# Altium schematic sheet JacdacRp2040BrainEc30 124.SchDoc("JacdacRp2040BrainEc30 124")
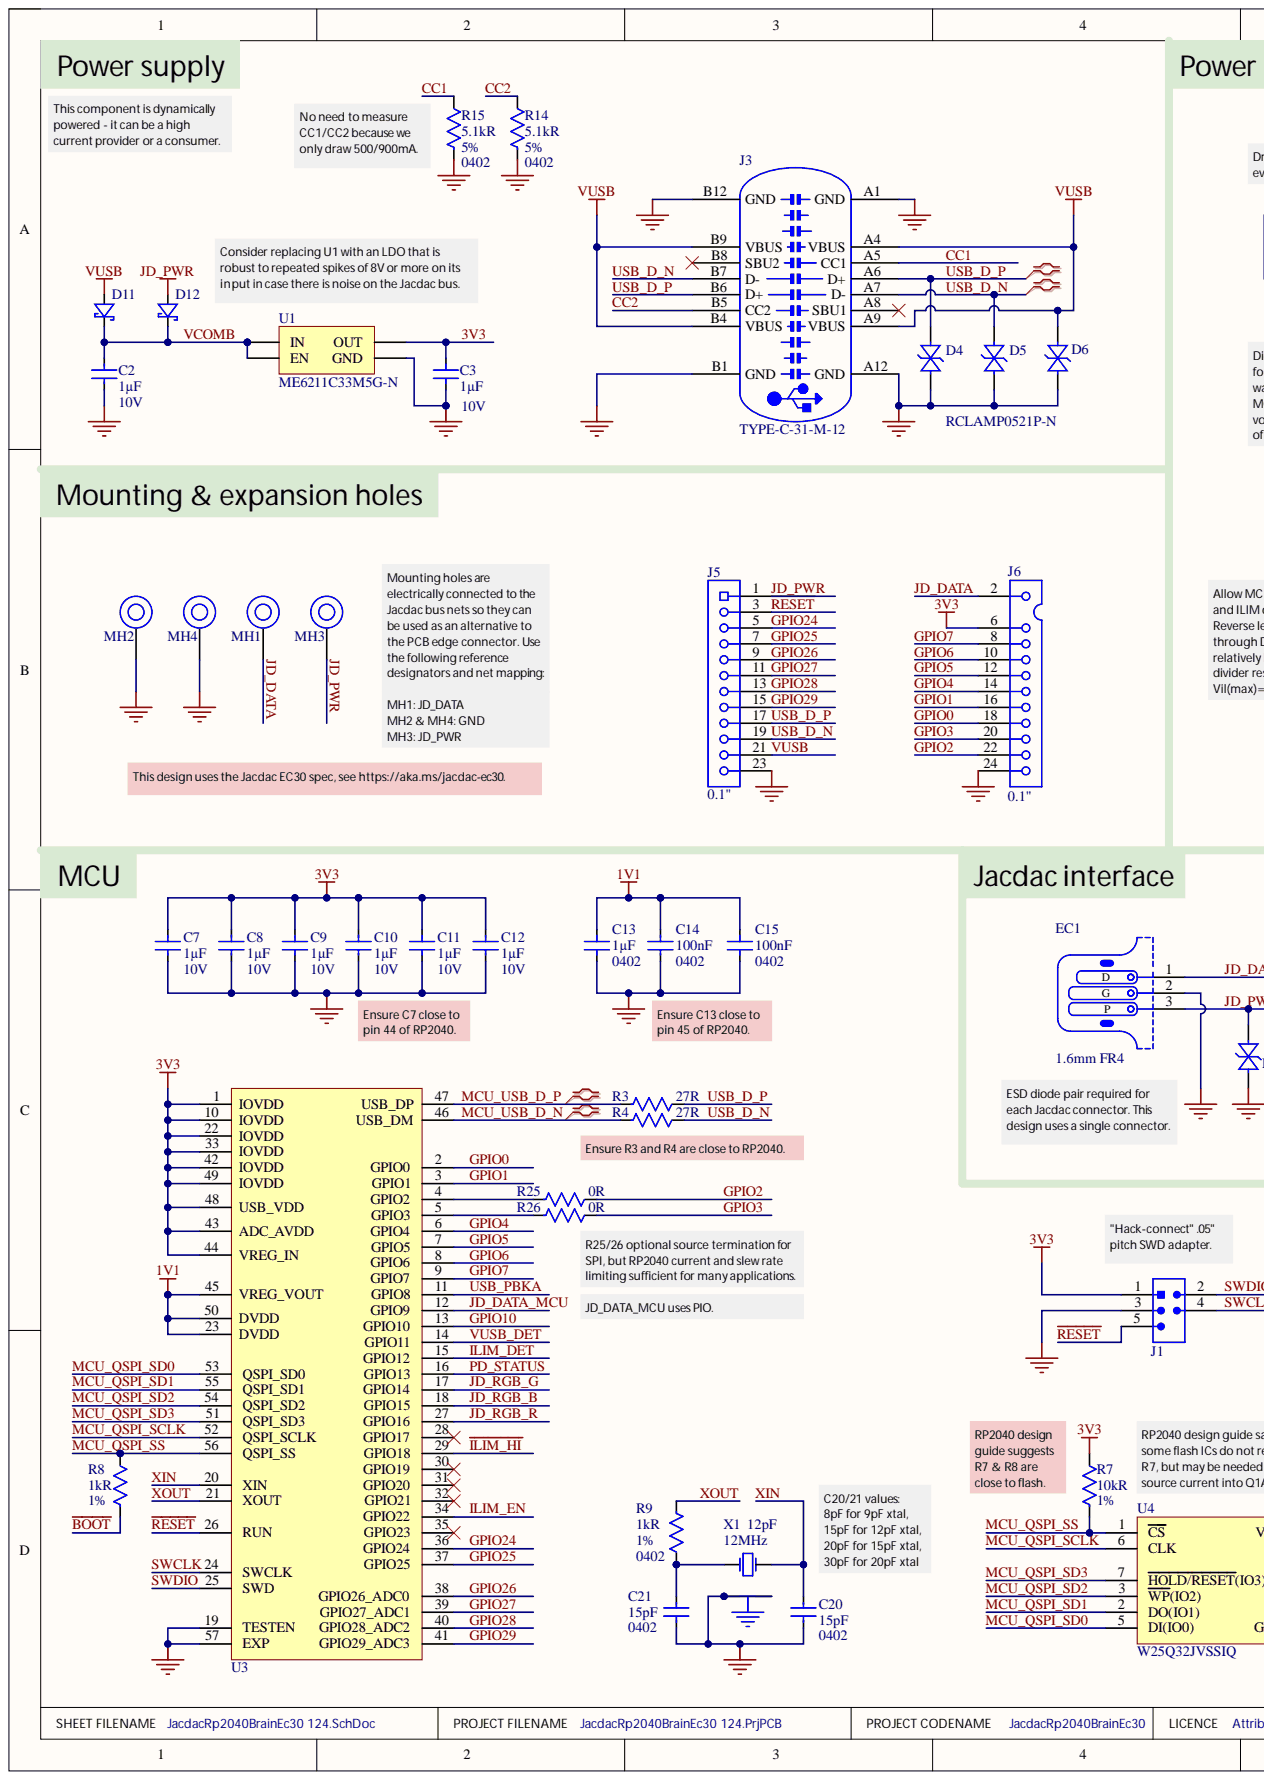
[diagram p0: JacdacRp2040BrainEc30 124.SchDoc, left]
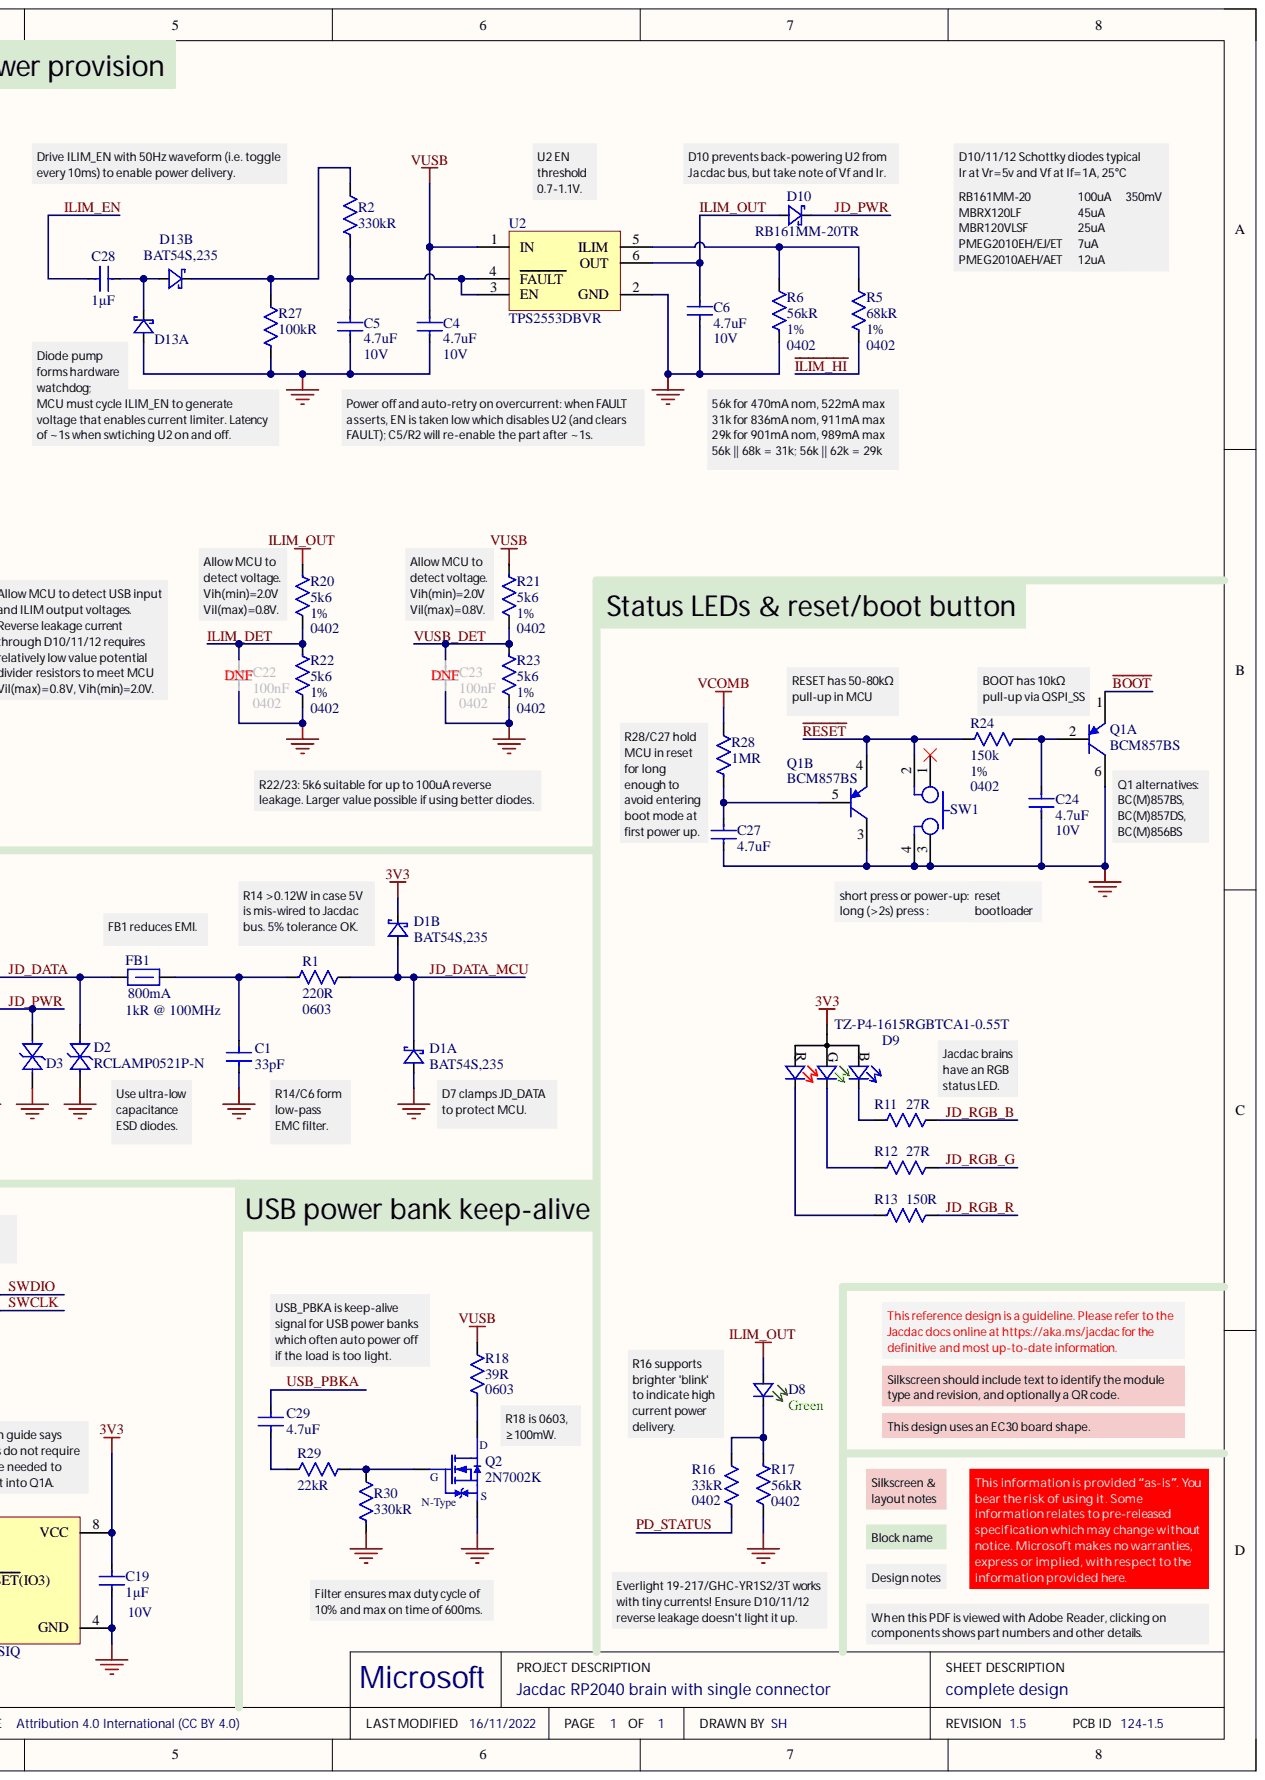
[diagram p1: JacdacRp2040BrainEc30 124.SchDoc, right]

## Parts
C1  Capacitance: 33pF
C2  Capacitance: 1µF  Voltage Rating: 10V
C3  Capacitance: 1µF  Voltage Rating: 10V
C4  Capacitance: 4.7uF  Voltage Rating: 10V
C5  Capacitance: 4.7uF  Voltage Rating: 10V
C6  Capacitance: 4.7uF  Voltage Rating: 10V
C7  Capacitance: 1µF  Voltage Rating: 10V
C8  Capacitance: 1µF  Voltage Rating: 10V
C9  Capacitance: 1µF  Voltage Rating: 10V
C10  Capacitance: 1µF  Voltage Rating: 10V
C11  Capacitance: 1µF  Voltage Rating: 10V
C12  Capacitance: 1µF  Voltage Rating: 10V
C13  Capacitance: 1µF  Case Code (Imperial): 0402
C14  Capacitance: 100nF  Case Code (Imperial): 0402
C15  Capacitance: 100nF  Case Code (Imperial): 0402
C19  Capacitance: 1µF  Voltage Rating: 10V
C20  Capacitance: 15pF  Case Code (Imperial): 0402
C21  Capacitance: 15pF  Case Code (Imperial): 0402
C22  Capacitance: 100nF  Case Code (Imperial): 0402
C23  Capacitance: 100nF  Case Code (Imperial): 0402
C24  Capacitance: 4.7uF  Voltage Rating: 10V
C27  Capacitance: 4.7uF
C28  Capacitance: 1µF
C29  Capacitance: 4.7uF
D1  Manufacturer Part Number: BAT54S,235  (parts A,B)
D2  Manufacturer Part Number: RCLAMP0521P-N
D3  (no value)
D4  Manufacturer Part Number: RCLAMP0521P-N
D5  Manufacturer Part Number: RCLAMP0521P-N
D6  Manufacturer Part Number: RCLAMP0521P-N
D8  Color: Green
D9  Manufacturer Part Number: TZ-P4-1615RGBTCA1-0.55T
D10  Manufacturer Part Number: RB161MM-20TR
D11  (no value)
D12  (no value)
D13  Manufacturer Part Number: BAT54S,235  (parts A,B)
EC1  PCB Type: 1.6mm FR4
FB1  Current Rating: 800mA  Impedance: 1kR @ 100MHz
J1  (no value)
J3  Manufacturer Part Number: TYPE-C-31-M-12
J5  (no value)
J6  (no value)
MH1  (no value)
MH2  (no value)
MH3  (no value)
MH4  (no value)
Q1  (no value)  (parts A,B)
Q2  Manufacturer Part Number: 2N7002K
R1  Case Code (Imperial): 0603  Resistance: 220R
R2  Resistance: 330kR
R3  Resistance: 27R
R4  Resistance: 27R
R5  Case Code (Imperial): 0402  Resistance: 68kR  Tolerance: 1%
R6  Case Code (Imperial): 0402  Resistance: 56kR  Tolerance: 1%
R7  Resistance: 10kR  Tolerance: 1%
R8  Resistance: 1kR  Tolerance: 1%
R9  Case Code (Imperial): 0402  Resistance: 1kR  Tolerance: 1%
R11  Resistance: 27R
R12  Resistance: 27R
R13  Resistance: 150R
R14  Case Code (Imperial): 0402  Resistance: 5.1kR  Resistance Tolerance: 5%
R15  Case Code (Imperial): 0402  Resistance: 5.1kR  Resistance Tolerance: 5%
R16  Case Code (Imperial): 0402  Resistance: 33kR
R17  Case Code (Imperial): 0402  Resistance: 56kR
R18  Case Code (Imperial): 0603  Resistance: 39R
R20  Case Code (Imperial): 0402  Resistance: 5k6  Tolerance: 1%
R21  Case Code (Imperial): 0402  Resistance: 5k6  Tolerance: 1%
R22  Case Code (Imperial): 0402  Resistance: 5k6  Tolerance: 1%
R23  Case Code (Imperial): 0402  Resistance: 5k6  Tolerance: 1%
R24  Resistance: 150k  Tolerance: 1%
R25  Resistance: 0R
R26  Resistance: 0R
R27  Resistance: 100kR
R28  Resistance: 1MR
R29  Resistance: 22kR
R30  Resistance: 330kR
SW1  (no value)
U1  Manufacturer Part Number: ME6211C33M5G-N
U2  Manufacturer Part Number: TPS2553DBVR
U3  (no value)
U4  Manufacturer Part Number: W25Q32JVSSIQ
X1  Frequency: 12MHz  Loading capacitance: 12pF

## Nets
1V1: C13.2 C14.2 C15.2 U3.23(DVDD) U3.45(VREG_VOUT) U3.50(DVDD)
GND: C1.1 C2.1 C3.1 C4.1 C5.1 C6.1 C7.1 C8.1 C9.1 C10.1 C11.1 C12.1 C13.1 C14.1 C15.1 C19.1 C20.2 C21.2 C22.1 C23.1 C24.1 C27.1 D1.1 D2.2 D3.2 D4.2 D5.2 D6.2 D13.1 EC1.2 J1.3 J3.A1(GND) J3.A12(GND) J3.B1(GND) J3.B12(GND) J5.23 J6.24 MH2.1 MH4.1 Q1.3 Q1.6 Q2.2 R6.1 R14.2 R15.2 R17.2 R22.1 R23.1 R27.1 R30.2 SW1.3 SW1.4 U1.2(GND) U2.2(GND) U3.19(TESTEN) U3.57(EXP) U4.4(GND) X1.2 X1.4
3V3: C3.2 C7.2 C8.2 C9.2 C10.2 C11.2 C12.2 C19.2 D1.2 D9.1 J1.1 J6.6 R7.1 U1.5(OUT) U3.1(IOVDD) U3.10(IOVDD) U3.22(IOVDD) U3.33(IOVDD) U3.42(IOVDD) U3.43(ADC_AVDD) U3.44(VREG_IN) U3.48(USB_VDD) U3.49(IOVDD) U4.8(VCC)
~{BOOT}: Q1.1 R8.2
CC1: J3.A5(CC1) R15.1
CC2: J3.B5(CC2) R14.1
GPIO0: J6.18 U3.2(GPIO0)
GPIO1: J6.16 U3.3(GPIO1)
GPIO2: J6.22 R25.2
GPIO3: J6.20 R26.2
GPIO4: J6.14 U3.6(GPIO4)
GPIO5: J6.12 U3.7(GPIO5)
GPIO6: J6.10 U3.8(GPIO6)
GPIO7: J6.8 U3.9(GPIO7)
GPIO10: U3.13(GPIO10)
GPIO24: J5.5 U3.36(GPIO24)
GPIO25: J5.7 U3.37(GPIO25)
GPIO26: J5.9 U3.38(GPIO26_ADC0)
GPIO27: J5.11 U3.39(GPIO27_ADC1)
GPIO28: J5.13 U3.40(GPIO28_ADC2)
GPIO29: J5.15 U3.41(GPIO29_ADC3)
~{ILIM_HI}: R5.1 U3.29(GPIO18)
ILIM_DET: C22.2 R20.1 R22.2 U3.15(GPIO12)
ILIM_EN: C28.2 U3.34(GPIO22)
ILIM_OUT: C6.2 D8.2 D10.2 R20.2 U2.6(OUT)
JD_DATA: D2.1 EC1.1 FB1.1 J6.2 MH1.1
JD_DATA_MCU: D1.3 R1.2 U3.12(GPIO9)
JD_PWR: D3.1 D10.1 D12.2 EC1.3 J5.1 MH3.1
JD_RGB_B: R11.2 U3.18(GPIO15)
JD_RGB_G: R12.2 U3.17(GPIO14)
JD_RGB_R: R13.2 U3.27(GPIO16)
MCU_QSPI_SCLK: U3.52(QSPI_SCLK) U4.6(CLK)
MCU_QSPI_SD0: U3.53(QSPI_SD0) U4.5(DI(IO0))
MCU_QSPI_SD1: U3.55(QSPI_SD1) U4.2(DO(IO1))
MCU_QSPI_SD2: U3.54(QSPI_SD2) U4.3(WP(IO2))
MCU_QSPI_SD3: U3.51(QSPI_SD3) U4.7(HOLD/RESET(IO3))
MCU_QSPI_SS: R7.2 R8.1 U3.56(QSPI_SS) U4.1(CS)
MCU_USB_D_N: R4.1 U3.46(USB_DM)
MCU_USB_D_P: R3.1 U3.47(USB_DP)
PD_STATUS: R16.1 U3.16(GPIO13)
~{RESET}: J1.5 J5.3 Q1.4 R24.1 SW1.2 U3.26(RUN)
SWCLK: J1.4 U3.24(SWCLK)
SWDIO: J1.2 U3.25(SWD)
USB_D_N: D5.1 J3.A7(D-) J3.B7(D-) J5.19 R4.2
USB_D_P: D4.1 J3.A6(D+) J3.B6(D+) J5.17 R3.2
USB_PBKA: C29.1 U3.11(GPIO8)
VCOMB: C2.2 D11.1 D12.1 R28.1 U1.1(IN) U1.3(EN)
VUSB: C4.2 D6.1 D11.2 J3.A4(VBUS) J3.A9(VBUS) J3.B4(VBUS) J3.B9(VBUS) J5.21 R18.2 R21.2 U2.1(IN)
VUSB_DET: C23.2 R21.1 R23.2 U3.14(GPIO11)
XIN: C20.1 U3.20(XIN) X1.3
XOUT: R9.2 U3.21(XOUT)
NetC1_2: C1.2 FB1.2 R1.1
NetC5_2: C5.2 R2.2 U2.3(EN) U2.4(FAULT)
NetC21_1: C21.1 R9.1 X1.1
NetC24_2: C24.2 Q1.2 R24.2
NetC27_2: C27.2 Q1.5 R28.2
NetC28_1: C28.1 D13.3
NetC29_2: C29.2 R29.2
NetD8_1: D8.1 R16.2 R17.1
NetD9_2: D9.2 R13.1
NetD9_3: D9.3(G) R12.1
NetD9_4: D9.4 R11.1
NetD13_2: D13.2 R2.1 R27.2
NetQ2_1: Q2.1 R29.1 R30.1
NetQ2_3: Q2.3 R18.1
NetR5_2: R5.2 R6.2 U2.5(ILIM)
NetR25_1: R25.1 U3.4(GPIO2)
NetR26_1: R26.1 U3.5(GPIO3)

Not connected: J3 A8(SBU1) B8(SBU2); SW1 1; U3 28(GPIO17) 30(GPIO19) 31(GPIO20) 32(GPIO21) 35(GPIO23)

## Library data of the parts
C1 = (no symbol name): CAP CER 33PF 50V NP0 0402; Manufacturer: Samsung; Manufacturer Part Number: CL05C330JB51PNC; Tolerance: 5%; Case Code (Imperial): 0402; Case Code (Metric): 1005; Case/Package: 0402; Category: Capacitors; ComponentLink1Description: Samsung datasheet online; ComponentLink1URL: http://www.samsungsem.com/kr/support/library/product-catalog/mlcc.jsp; Max Operating Temperature: 125°C; Min Operating Temperature: -55°C; Ratings: AEC-Q200; Supplier 1: Digi-Key; Supplier 2: Mouser; Supplier 3: Future Electronics; Supplier Part Number 1: 1276-6515-1-ND; Supplier Part Number 2: 187-CL05C330JB51PNC; Supplier Part Number 3: 6022520; Voltage Rating: 50V
C2 C3 C7-C13 C19 C28 = (no symbol name): CAP CER 1UF 10V X5R 0402; Manufacturer: Samsung; Manufacturer Part Number: CL05A105KP5NNNC; Material: Ceramic; Tolerance: 10%; Case Code (Metric): 1005; Case/Package: 0402; Category: Capacitors; ComponentLink1Description: Online datasheet; ComponentLink1URL: https://datasheet.ciiva.com/15195/getdatasheetpartid-358390-15195630.pdf; Contact Plating: Tin; Dielectric: X5R; Max Operating Temperature: 85°C; Min Operating Temperature: -55°C; Supplier 1: Digi-Key; Supplier 2: Mouser; Supplier 3: Farnell; Supplier Part Number 1: 1276-1076-1-ND; Supplier Part Number 2: 187-CL05A105KP5NNNC; Supplier Part Number 3: 3013329
  C2 C3 C7-C12 C19: Case Code (Imperial): 0402
  C13: Voltage Rating: 10V
  C28: Case Code (Imperial): 0402; Voltage Rating: 10V
C4-C6 C24 C27 C29 = (no symbol name): CAP CER 4.7UF 10V X5R 0402; Manufacturer: Samsung; Manufacturer Part Number: CL05A475MP5NRNC; Tolerance: 20%; Case Code (Imperial): 0402; Case Code (Metric): 1005; Case/Package: 0402; Category: Ceramic Capacitors; ComponentLink1Description: Online datasheet; ComponentLink1URL: https://datasheet.ciiva.com/25786/cl05a475mp5nrnc-25786963.pdf; Dielectric: X5R; Max Operating Temperature: 85°C; Min Operating Temperature: -55°C; RoHS Compliant: Yes; Series: CL; Supplier 1: Digi-Key; Supplier 2: Future Electronics; Supplier Part Number 1: 1276-1482-2-ND; Supplier Part Number 2: 2103694
  C27 C29: Voltage Rating: 10V
C14 C15 C22 C23 = (no symbol name): CAP CER 0.1UF 10V X7R 0402; Manufacturer: Samsung; Manufacturer Part Number: CL05B104KP5NNNC; Tolerance: 10%; Case Code (Metric): 1005; Case/Package: 0402; Category: Ceramic Capacitors; ComponentLink1Description: Samsung datasheet online; ComponentLink1URL: https://datasheet.ciiva.com/15165/getdatasheetpartid-37100-15165107.pdf; Contact Plating: Tin; Dielectric: X7R; Max Operating Temperature: 125°C; Min Operating Temperature: -55°C; Supplier 1: Digi-Key; Supplier 2: Mouser; Supplier 3: Farnell; Supplier 4: Newark; Supplier 5: Future Electronics; Supplier Part Number 1: 1276-1002-1-ND; Supplier Part Number 2: 187-CL05B104KP5NNNC; Supplier Part Number 3: 3013351; Supplier Part Number 4: 82AC9219; Supplier Part Number 5: 9032525; Voltage Rating: 10V
C20 C21 = (no symbol name): CAP CER 15PF 50V C0G/NP0 0402; Manufacturer: Samsung; Manufacturer Part Number: CL05C150JB5NNNC; Dielectric: C0G; Material: Ceramic; Temperature Coefficient: 300ppm/°C; Tolerance: 5%; Case Code (Metric): 1005; Case/Package: 0402; Category: Ceramic Capacitors; ComponentLink1Description: Online datasheet; ComponentLink1URL: https://datasheet.ciiva.com/15195/getdatasheetpartid-497170-15195669.pdf; Construction: Flat; Contact Plating: Tin; Max Operating Temperature: 125°C; Min Operating Temperature: -55°C; RoHS Compliant: Yes; Series: CL; Supplier 1: Digi-Key; Supplier 2: Mouser; Supplier Part Number 1: 1276-1179-1-ND; Supplier Part Number 2: 187-CL05C150JB5NNNC; Voltage: 50V; Voltage Rating: 50V
D1 D13 = (no symbol name): DIODE ARRAY SCHOTTKY 30V SOT23; Manufacturer: Nexperia; Category: Rectifier Diodes; ComponentLink1Description: NXP datasheet online; ComponentLink1URL: https://assets.nexperia.com/documents/data-sheet/BAT54_SER.pdf; Supplier 1: Digi-Key; Supplier 2: Mouser; Supplier 3: RSComponents; Supplier Part Number 1: 1727-1868-1-ND; Supplier Part Number 2: 771-BAT54S235; Supplier Part Number 3: 1707990
D2 D4-D6 = (no symbol name): Bidirectional 5V (Max) 6V 16V 3.5A (8/20us) 0402 TVS RoHS; Manufacturer: Born Semiconductor; ComponentLink1Description: Online datasheet; ComponentLink1URL: https://datasheet.lcsc.com/szlcsc/Bourne-Semicon-Shenzhen-RCLAMP0521P-N_C316043.pdf; Manufacturer 1: Born Semiconductor; Manufacturer Part Number 1: RCLAMP0521P-N; Package: 0402; Supplier 1: LCSC; Supplier Part Number 1: C316043
D3 = (no symbol name): Bidirectional 5V (Max) 6V 16V 3.5A (8/20us) 0402 TVS RoHS; Manufacturer: Born Semiconductor; Manufacturer Part Number: RCLAMP0521P-N; ComponentLink1Description: Online datasheet; ComponentLink1URL: https://datasheet.lcsc.com/szlcsc/Bourne-Semicon-Shenzhen-RCLAMP0521P-N_C316043.pdf; Manufacturer 1: Born Semiconductor; Manufacturer Part Number 1: RCLAMP0521P-N; Package: 0402; Supplier 1: LCSC; Supplier Part Number 1: C316043
D8 = (no symbol name): LED GREEN DIFFUSED 0603 SMD; Manufacturer: Everlight Elec; Manufacturer Part Number: 19-217/GHC-YR1S2/3T; Case Code (Imperial): 0603; Case/Package: 0603; Colour: Green; ComponentLink1Description: Online datasheet; ComponentLink1URL: https://datasheet.lcsc.com/lcsc/1811101510_Everlight-Elec-19-217-GHC-YR1S2-3T_C72043.pdf; Manufacturer 1: Everlight Elec; Manufacturer Part Number 1: 19-217/GHC-YR1S2/3T; Supplier 1: LCSC; Supplier Part Number 1: C72043
D9 = (no symbol name): 1615 Light Emitting Diodes (LED) RoHS; Manufacturer: TUOZHAN; Category: Optoelectronics; ComponentLink1Description: Online datasheet; ComponentLink1URL: https://datasheet.lcsc.com/szlcsc/2009041238_TUOZHAN-TZ-P4-1615RGBTCA1-0-55T_C779813.pdf; Manufacturer 1: TUOZHAN; Manufacturer Part Number 1: TZ-P4-1615RGBTCA1-0.55T; Package: 1615; Size / Dimension: 1.6x1.5mm; Supplier 1: LCSC; Supplier Part Number 1: C779813
D10-D12 = (no symbol name): ROHM - RB161MM-20TR - Schottky-Gleichrichterdiode, 20 V, 1 A, Einfach, SOD-123FL, 2 Pin(s), 350 mV; Average Rectified Current: 1A; Max Reverse Voltage (DC): 25V; Case/Package: SOD; Category: Rectifier Diodes; ComponentLink1Description: Online datasheet; ComponentLink1URL: http://www.mouser.com/ds/2/348/rb161mm-20tr-e-1138856.pdf; Manufacturer: Rohm; Max Operating Temperature: 125°C; Number of Pins: 2; RoHS Compliant: Yes; Supplier 1: Digi-Key; Supplier 2: RSComponents; Supplier 3: Mouser; Supplier Part Number 1: RB161MM-20TR-ND; Supplier Part Number 2: 1246775; Supplier Part Number 3: 755-RB161MM-20TR
  D11 D12: Manufacturer Part Number: RB161MM-20TR
EC1 = (no symbol name): 3 pin Jacdac edge connector 1.27mm pitch; ComponentLink1Description: Yuliang JACDAC cable connector drawing online; ComponentLink1URL: https://github.com/microsoft/jacdac-ddk/tree/main/connector; ComponentLink2Description: JACDAC PCB edge connector spec onlin; ComponentLink2URL: https://github.com/microsoft/jacdac-ddk/tree/main/connector; Manufacturer Part Number: n/a
FB1 = (no symbol name): 0603 1k@100MHz 0.8A chip; Manufacturer: TDK; Manufacturer Part Number: MPZ1608S102ATA00; Core Material: Ferrite; DC Resistance (DCR): 300mR; Tolerance: 25%; Case Code (Imperial): 0603; Case Code (Metric): 1608; Case/Package: 0603; ComponentLink2Description: datasheet online; ComponentLink2URL: https://www.mouser.co.uk/datasheet/2/400/eads_commercial_power_mpz1608_en-923650.pdf; ComponentLink3Description: datasheet; Max DC Current: 800mA; Supplier 1: Digi-Key; Supplier 2: Mouser; Supplier Part Number 1: 445-8694-1-ND; Supplier Part Number 2: 810-MPZ1608S102ATA00; ComponentLink3URL: Y:\System\Altium\Libraries 2014\TDK\Datasheets\eads_commercial_power_mpz1608_en-923650.pdf
J1 = (no symbol name): Hack Connect Mini 1.27 mm pitch 2-row
J3 = (no symbol name): USB - Type C Female 16 USB Connectors RoHS; Manufacturer: Korean Hroparts Elec; Gender: Receptacle; Number of Positions: 16; Category: USB Connectors; ComponentLink1Description: Online datasheet; ComponentLink1URL: https://datasheet.lcsc.com/szlcsc/1811131825_Korean-Hroparts-Elec-TYPE-C-31-M-12_C165948.pdf; Manufacturer 1: Korean Hroparts Elec; Manufacturer Part Number 1: TYPE-C-31-M-12; Orientation: Right Angle; Supplier 1: LCSC; Supplier Part Number 1: C165948
J5 J6 = (no symbol name): 2.54mm, 12x1 way, vertical, TH; Manufacturer: Generic; Manufacturer Part Number: TBD
MH1-MH4 = (no symbol name): Mounting Hole 7.0mm keepout, 4.4mm annualar ring and 3.1mm Drill PTH; Hole Size: M3; Annular Ring: 4.4mm; Hole Clearance: 7.0mm; Hole Diameter: 3.1mm; Hole Type: PTH
Q1 = (no symbol name): BJT ARRAY, DUAL PNP, -45V, -100MA, 6-SOT-363; Manufacturer: NXP Semiconductors; Manufacturer Part Number: BCM857BS,115; Collector Base Voltage (VCBO): 50V; Collector Emitter Breakdown Voltage: 45V; Emitter Base Voltage (VEBO): 5V; Frequency: 175MHz; Max Collector Current: 100mA; Number of Elements: 2; Test Current: 5mA; Tolerance: 5%; Zener Voltage: 3.3V; Case/Package: SOT-363; Collector Emitter Voltage (VCEO): 45V; ComponentLink1Description: NXP datasheet online; ComponentLink1URL: https://assets.nexperia.com/documents/data-sheet/BCM857BV_BS_DS.pdf; Contact Plating: Tin; Element Configuration: Dual; Gain Bandwidth Product: 175MHz; Max Breakdown Voltage: 45V; Number of Pins: 6; Polarity: PNP; Transition Frequency: 175MHz; Weight: 0.01lb
Q2 = (no symbol name): MOSFET Transistor, N Channel, 300 mA, 60 V, 2 ohm, 10 V, 2.5 V; Manufacturer: ON Semiconductor / Fairchild; Continuous Drain Current (ID): 300mA; Drain to Source Breakdown Voltage: 60V; Drain to Source Resistance: 2R; Element Configuration: Single; Gate to Source Voltage (Vgs): 20V; Input Capacitance: 50pF; Max Power Dissipation: 350mW; Number of Elements: 1; Polarity: N-CHANNEL; Threshold Voltage: 2.5V; Turn-Off Delay Time: 30ns; Turn-On Delay Time: 5ns; Case/Package: SOT-23; Category: MOSFETs; ComponentLink1Description: Online datasheet; ComponentLink1URL: https://www.onsemi.com/pdf/datasheet/2n7002k-d.pdf; Contact Plating: Tin,Matte; Drain to Source Voltage (Vdss): 60V; Drain-source On Resistance-Max: 2R; Max Operating Temperature: 150°C; Min Operating Temperature: -55°C; Number of Pins: 3; On-State Resistance: 2R; Power Dissipation: 350mW; Rds On Max: 2R; Supplier 1: Digi-Key; Supplier 2: Mouser; Supplier 3: Farnell; Supplier Part Number 1: 2N7002KCT-ND; Supplier Part Number 2: 512-2N7002K; Supplier Part Number 3: 2453382; Voltage: 60V
R1 = (no symbol name): Res Thick Film 0603 220 Ohm 1% 0.1W(1/10W) ±100ppm/°C Pad SMD T/R; Manufacturer: Yageo; Manufacturer Part Number: RC0603FR-07220RL; Max Power Dissipation: 100mW; Voltage Rating: 50V; Case Code (Metric): 1608; Case/Package: 0603; Category: Chip SMD Resistors; ComponentLink4Description: online datasheet; ComponentLink4URL: https://datasheet.ciiva.com/46395/443971-46395002.pdf; Construction: Rectangular; Features: Moisture Resistant; Manufacturer 1: Yageo; Manufacturer Part Number 1: RC0603FR-07220RL; Max Operating Temperature: 155°C; Min Operating Temperature: -55°C; Number of Pins: 2; Number of Terminations: 2; Power Rating: 100mW; Resistance Tolerance: 1%; Resistor Type: Thick Film; RoHS Compliant: Yes; Series: RC; Size Code: 0603; Supplier 1: Digi-Key; Supplier 2: Farnell; Supplier 3: Mouser; Supplier 4: RSComponents; Supplier Part Number 1: 311-220HRCT-ND; Supplier Part Number 2: 2421863; Supplier Part Number 3: 603-RC0603FR-07220RL; Supplier Part Number 4: 1995497; Temperature Coefficient: 100ppm/°C; Termination: SMD/SMT; Tolerance: 1%; Voltage Rating (DC): 50V
R2 R30 = (no symbol name): RES SMD 330K OHM 1% 1/16W 0402; Manufacturer: Yageo; Manufacturer Part Number: RC0402FR-07330KL; Max Power Dissipation: 63mW; Temperature Coefficient: 100ppm/°C; Voltage Rating: 50V; Case Code (Imperial): 0402; Case Code (Metric): 1005; Case/Package: 0402; Category: Chip SMD Resistors; ComponentLink1Description: Online datasheet; ComponentLink1URL: https://datasheet.ciiva.com/2518/pyu-r-int-thick-7-2518994.pdf?src-supplier=Digi-Key; Construction: Rectangular; Features: Moisture Resistant; Max Operating Temperature: 155°C; Min Operating Temperature: -55°C; Number of Terminations: 2; Power Rating: 63mW; Resistance Tolerance: 1%; Resistor Type: Thick Film; RoHS Compliant: Yes; Series: RC; Size Code: 0402; Supplier 1: Digi-Key; Supplier 2: Mouser; Supplier 3: Farnell; Supplier Part Number 1: 311-330KLRCT-ND; Supplier Part Number 2: 603-RC0402FR-07330KL; Supplier Part Number 3: 9239537; Termination: SMD/SMT; Tolerance: 1%
R3 R4 R11 R12 = (no symbol name): RES SMD 27 OHM 1% 1/16W 0402; Manufacturer: Yageo; Manufacturer Part Number: RC0402FR-0727RL; Max Power Dissipation: 63mW; Power Rating: 62.5mW; Temperature Coefficient: 100ppm/°C; Voltage Rating: 50V; Case Code (Imperial): 0402; Case Code (Metric): 1005; Case/Package: 0402; Category: Chip SMD Resistors; ComponentLink1Description: Online datasheeet; ComponentLink1URL: https://datasheet.ciiva.com/11808/0900766b80c66297-11808450.pdf; Construction: Rectangular; Features: Moisture Resistant; Max Operating Temperature: 155°C; Min Operating Temperature: -55°C; Number of Terminations: 2; Resistance Tolerance: 1%; Resistor Type: Thick Film; RoHS Compliant: Yes; Series: RC; Size Code: 0402; Supplier 1: Digi-Key; Supplier 2: Farnell; Supplier 3: Future Electronics; Supplier Part Number 1: YAG3086CT-ND; Supplier Part Number 2: 9239049; Supplier Part Number 3: 2577120; Technology: THICK FILM; Termination: SMD/SMT; Tolerance: 1%; Weight: 2.3E-05oz
R5 = (no symbol name): RES SMD 68K OHM 1% 1/16W 0402; Manufacturer: Yageo; Manufacturer Part Number: RC0402FR-0768KL; Max Power Dissipation: 63mW; Temperature Coefficient: 100ppm/°C; Voltage Rating: 50V; Case Code (Metric): 1005; Case/Package: 0402; Category: Resistors; ComponentLink2Description: Online datasheet; ComponentLink2URL: https://datasheet.ciiva.com/2453/pyu-r-int-thick-7-2453361.pdf; Features: Moisture Resistant; Max Operating Temperature: 155°C; Min Operating Temperature: -55°C; Number of Terminations: 2; Power Rating: 63mW; Resistor Type: Thick Film; Termination: SMD/SMT; Working Voltage: 50V
R6 R17 = (no symbol name): RES SMD 56K OHM 1% 1/16W 0402; Manufacturer: Yageo; Manufacturer Part Number: RC0402FR-0756KL; Max Power Dissipation: 63mW; Temperature Coefficient: 100ppm/°C; Voltage Rating: 50V; Case Code (Metric): 1005; Case/Package: 0402; Category: Resistors; ComponentLink2Description: Online datasheet; ComponentLink2URL: https://datasheet.ciiva.com/2453/pyu-r-int-thick-7-2453361.pdf; Features: Moisture Resistant; Max Operating Temperature: 155°C; Min Operating Temperature: -55°C; Number of Terminations: 2; Power Rating: 63mW; Resistor Type: Thick Film; Termination: SMD/SMT; Working Voltage: 50V
  R17: Tolerance: 1%
R7 = (no symbol name): RES SMD 10K OHM 1% 1/16W 0402; Manufacturer: Yageo; Manufacturer Part Number: RC0402FR-0710KL; Power Rating: 62.5mW; Temperature Coefficient: 100ppm/°C; Voltage Rating: 50V; Case Code (Imperial): 0402; Case Code (Metric): 1005; Case/Package: 0402; Category: Resistors; ComponentLink3Description: Online datasheet; ComponentLink3URL: https://datasheet.ciiva.com/7849/1686128-7849814.pdf; Lead Pitch: 0.2mm; Manufacturer 1: Yageo; Manufacturer Part Number 1: RC0402FR-0710KL; Max Operating Temperature: 155°C; Min Operating Temperature: -55°C; Number of Pins: 2; Number of Terminations: 2; Resistor Type: Thick Film; Supplier 1: Digi-Key; Supplier 2: Mouser; Supplier 3: Farnell; Supplier Part Number 1: 311-10.0KLRCT-ND; Supplier Part Number 2: 603-RC0402FR-0710KL; Supplier Part Number 3: 2363463; Termination: SMD/SMT; Voltage Rating (DC): 50V; Working Voltage: 50V
R8 R9 = (no symbol name): RES SMD 1K OHM 1% 1/16W 0402; Manufacturer: Yageo; Manufacturer Part Number: RC0402FR-071KL; Power Rating: 62.5mW; Temperature Coefficient: 100ppm/°C; Voltage Rating: 50V; Case Code (Metric): 1005; Case/Package: 0402; Category: Resistors; ComponentLink2Description: Online datasheet; ComponentLink2URL: https://datasheet.ciiva.com/12666/pyu-rc0402-51-rohs-l-6-r-12666741.pdf; Max Operating Temperature: 155°C; Min Operating Temperature: -55°C; Number of Pins: 2; Resistor Type: Thick Film; Supplier 1: Digi-Key; Supplier 2: Mouser; Supplier 3: Farnell; Supplier Part Number 1: 311-1.00KLRDKR-ND; Supplier Part Number 2: 603-RC0402FR-071KL; Supplier Part Number 3: 2132441; Termination: SMD/SMT; Voltage Rating (DC): 50V
  R8: Case Code (Imperial): 0402
R13 = (no symbol name): RES SMD 150 OHM 1% 1/16W 0402; Manufacturer: Yageo; Manufacturer Part Number: RC0402FR-07150RL; Max Power Dissipation: 63mW; Power Rating: 62.5mW; Temperature Coefficient: 100ppm/°C; Voltage Rating: 50V; Case Code (Imperial): 0402; Case Code (Metric): 1005; Case/Package: 0402; Category: Chip SMD Resistors; ComponentLink1Description: ine datasheet; ComponentLink1URL: https://datasheet.ciiva.com/11808/0900766b80c66297-11808422.pdf; Construction: Rectangular; Features: Moisture Resistant; Max Operating Temperature: 155°C; Min Operating Temperature: -55°C; Number of Terminations: 2; Resistance Tolerance: 1%; Resistor Type: Thick Film; RoHS Compliant: Yes; Series: RC; Supplier 1: Digi-Key; Supplier 2: Mouser; Supplier 3: Farnell; Supplier Part Number 1: 311-150LRCT-ND; Supplier Part Number 2: 603-RC0402FR-07150RL; Supplier Part Number 3: 2421893; Technology: THICK FILM; Termination: SMD/SMT; Tolerance: 1%; Working Voltage: 50V
R14 R15 = (no symbol name): RES SMD 5.1K OHM 5% 1/16W 0402; Manufacturer: Yageo; Manufacturer Part Number: RC0402JR-075K1L; Max Power Dissipation: 63mW; Power Rating: 62.5mW; Temperature Coefficient: 100ppm/°C; Voltage Rating: 50V; Case Code (Metric): 1005; Case/Package: 0402; Category: Chip SMD Resistors; ComponentLink2Description: Onnline datasheet; ComponentLink2URL: https://datasheet.ciiva.com/16740/pyu-rc0402-51-rohs-l-6-r-16740925.pdf; Construction: Rectangular; Features: Moisture Resistant; Max Operating Temperature: 155°C; Min Operating Temperature: -55°C; Number of Terminations: 2; Resistor Type: Thick Film; RoHS Compliant: Yes; Series: RC; Size Code: 0402; Supplier 1: Digi-Key; Supplier 2: Newark; Supplier 3: Mouser; Supplier 4: Farnell; Supplier 5: Farnell; Supplier Part Number 1: 311-5.1KJRCT-ND; Supplier Part Number 2: 68R0016; Supplier Part Number 3: 603-RC0402JR-075K1L; Supplier Part Number 4: 3495714; Supplier Part Number 5: 1799293; Termination: SMD/SMT; Tolerance: 5%; Voltage Rating (DC): 50V; Weight: 2.3E-05oz
R16 = (no symbol name): RES SMD 33K OHM 1% 1/16W 0402; Manufacturer: Yageo; Manufacturer Part Number: RC0402FR-0733KL; Max Power Dissipation: 63mW; Power Rating: 62.5mW; Temperature Coefficient: 100ppm/°C; Voltage Rating: 50V; Case Code (Metric): 1005; Case/Package: 0402; Category: Chip SMD Resistors; ComponentLink1Description: Online datasheet; ComponentLink1URL: https://datasheet.ciiva.com/11819/0900766b80c66297-11819487.pdf; Construction: Rectangular; Features: Moisture Resistant; Max Operating Temperature: 155°C; Min Operating Temperature: -55°C; Number of Pins: 2; Number of Terminations: 2; Resistance Tolerance: 1%; Resistor Type: Thick Film; RoHS Compliant: Yes; Series: RC; Size Code: 0402; Supplier 1: Farnell; Supplier 2: Digi-Key; Supplier 3: Mouser; Supplier 4: RSComponents; Supplier Part Number 1: 9239413; Supplier Part Number 2: 311-33.0KLRCT-ND; Supplier Part Number 3: 603-RC0402FR-0733KL; Supplier Part Number 4: 1987757; Technology: THICK FILM; Termination: SMD/SMT; Tolerance: 1%; Voltage Rating (DC): 50V
R18 = (no symbol name): RES SMD 39 OHM 1% 1/10W 0603; Manufacturer: Yageo; Manufacturer Part Number: RC0603FR-0739RL; Max Power Dissipation: 100mW; Temperature Coefficient: 100ppm/°C; Voltage Rating: 50V; Working Voltage: 75V; Case Code (Metric): 1608; Case/Package: 0603; Category: Chip SMD Resistors; ComponentLink1Description: Online datasheet; ComponentLink1URL: https://datasheet.ciiva.com/11819/0900766b80f96399-11819290.pdf; Construction: Rectangular; Features: Moisture Resistant; Max Operating Temperature: 155°C; Min Operating Temperature: -55°C; Number of Terminations: 2; Power Rating: 100mW; Resistance Tolerance: 1%; Resistor Type: Thick Film; RoHS Compliant: Yes; Series: RC; Technology: THICK FILM; Termination: SMD/SMT; Tolerance: 1%; Voltage Rating (DC): 50V
R20-R23 = (no symbol name): RES SMD 5K6 OHM 1% 1/16W 0402; Manufacturer: Yageo; Manufacturer Part Number: RC0402FR-075K6L; Power Rating: 62.5mW; Temperature Coefficient: 100ppm/°C; Voltage Rating: 50V; Case Code (Metric): 1005; Case/Package: 0402; Category: Resistors; ComponentLink3Description: Online datasheet; ComponentLink3URL: https://datasheet.ciiva.com/7849/1686128-7849814.pdf; Lead Pitch: 0.2mm; Manufacturer 1: Yageo; Manufacturer Part Number 1: RC0402FR-075K6L; Max Operating Temperature: 155°C; Min Operating Temperature: -55°C; Number of Pins: 2; Number of Terminations: 2; Resistor Type: Thick Film; Supplier 1: Digi-Key; Supplier 2: Farnell; Supplier 3: RSComponents; Supplier 4: Mouser; Supplier Part Number 1: 311-5.6KLRCT-ND; Supplier Part Number 2: 9239324; Supplier Part Number 3: 1987840; Supplier Part Number 4: 603-RC0402FR-075K6L; Termination: SMD/SMT; Voltage Rating (DC): 50V; Working Voltage: 50V
R24 = (no symbol name): RES SMD 150K OHM 1% 1/16W 0402; Manufacturer: Yageo; Manufacturer Part Number: RC0402FR-07150KL; Max Power Dissipation: 63mW; Temperature Coefficient: 100ppm/°C; Voltage Rating: 50V; Case Code (Imperial): 0402; Case Code (Metric): 1005; Case/Package: 0402; Category: Resistors; ComponentLink2Description: Online datasheet; ComponentLink2URL: https://datasheet.ciiva.com/2453/pyu-r-int-thick-7-2453361.pdf; Features: Moisture Resistant; Max Operating Temperature: 155°C; Min Operating Temperature: -55°C; Number of Terminations: 2; Power Rating: 63mW; Resistor Type: Thick Film; Termination: SMD/SMT; Working Voltage: 50V
R25 R26 = (no symbol name): RES SMD 0 OHM JUMPER 1/16W 0402; Manufacturer: Yageo; Manufacturer Part Number: RC0402JR-070RL; Max Power Dissipation: 63mW; Power Rating: 62.5mW; Temperature Coefficient: 200ppm/°C; Tolerance: 5%; Voltage Rating: 50V; Case Code (Imperial): 0402; Case Code (Metric): 1005; Case/Package: 0402; Category: Resistors; ComponentLink1Description: Online datasheet; ComponentLink1URL: https://datasheet.ciiva.com/2452/pyu-rc0402-51-rohs-l-6-2452608.pdf; Contact Plating: Tin,Matte; Features: Moisture Resistant; Max Operating Temperature: 155°C; Min Operating Temperature: -55°C; Number of Terminations: 2; Resistor Type: Thick Film; Supplier 1: Digi-Key; Supplier 2: Mouser; Supplier 3: Future Electronics; Supplier Part Number 1: 311-0.0JRCT-ND; Supplier Part Number 2: 603-RC0402JR-070RL; Supplier Part Number 3: 3375797; Termination: SMD/SMT
R27 = (no symbol name): RES SMD 100K OHM 1% 1/16W 0402; Manufacturer: Yageo; Manufacturer Part Number: RC0402FR-07100KL; Max Power Dissipation: 63mW; Temperature Coefficient: 100ppm/°C; Voltage Rating: 50V; Case Code (Imperial): 0402; Case Code (Metric): 1005; Case/Package: 0402; Category: Resistors; ComponentLink2Description: Online datasheet; ComponentLink2URL: https://datasheet.ciiva.com/2453/pyu-r-int-thick-7-2453361.pdf; Features: Moisture Resistant; Max Operating Temperature: 155°C; Min Operating Temperature: -55°C; Number of Terminations: 2; Power Rating: 63mW; Resistor Type: Thick Film; Supplier 1: Digi-Key; Supplier 2: Mouser; Supplier 3: Farnell; Supplier Part Number 1: 311-100KLRCT-ND; Supplier Part Number 2: 603-RC0402FR-07100KL; Supplier Part Number 3: 2131798; Termination: SMD/SMT; Tolerance: 1%; Working Voltage: 50V
R28 = (no symbol name): RES SMD 1M OHM 1% 1/16W 0402; Manufacturer: Yageo; Manufacturer Part Number: RC0402FR-071ML; Max Power Dissipation: 63mW; Temperature Coefficient: 100ppm/°C; Voltage Rating: 50V; Case Code (Imperial): 0402; Case Code (Metric): 1005; Case/Package: 0402; Category: Chip SMD Resistors; ComponentLink1Description: Online datasheet; ComponentLink1URL: https://datasheet.ciiva.com/11808/0900766b80c66297-11808125.pdf; Construction: Rectangular; Features: Moisture Resistant; Max Operating Temperature: 155°C; Min Operating Temperature: -55°C; Number of Terminations: 2; Power Rating: 63mW; Resistance Tolerance: 1%; Resistor Type: Thick Film; RoHS Compliant: Yes; Series: RC; Size Code: 0402; Supplier 1: Digi-Key; Supplier 2: Mouser; Supplier 3: Farnell; Supplier Part Number 1: 311-1.00MLRCT-ND; Supplier Part Number 2: 603-RC0402FR-071ML; Supplier Part Number 3: 2421892; Technology: THICK FILM; Termination: SMD/SMT; Tolerance: 1%
R29 = (no symbol name): RES SMD 22K OHM 1% 1/16W 0402; Manufacturer: Yageo; Manufacturer Part Number: RC0402FR-0722KL; Max Power Dissipation: 63mW; Temperature Coefficient: 100ppm/°C; Voltage Rating: 50V; Case Code (Imperial): 0402; Case Code (Metric): 1005; Case/Package: 0402; Category: Resistors; ComponentLink2Description: Online datasheet; ComponentLink2URL: https://datasheet.ciiva.com/2453/pyu-r-int-thick-7-2453361.pdf; Features: Moisture Resistant; Max Operating Temperature: 155°C; Min Operating Temperature: -55°C; Number of Terminations: 2; Power Rating: 63mW; Resistor Type: Thick Film; Termination: SMD/SMT; Tolerance: 1%; Working Voltage: 50V
SW1 = (no symbol name): SWITCH TACTILE SSPST 50mA @ 12VDC; Manufacturer: USAKRO; Manufacturer Part Number: UK-B0267-SP25Y; Actuator: Oval; Contact Form: SPST; Operating Force: 3.9 N; Switch Function: OFF - (ON); ComponentLink1Description: Online datasheet; ComponentLink1URL: https://datasheet.ciiva.com/18514/pts810-4mar13-18514167.pdf; Current Rating: 50 mA; Manufacturer 1: USAKRO; Manufacturer Part Number 1: UK-B0267-SP25Y; Mounting Style: SMD/SMT; Product Category: Tactile Switches; Supplier 1: LCSC; Supplier Part Number 1: C493283; Voltage Rating DC: 12 VDC
U1 = (no symbol name): 6V 260mV @ 200mA(typ) - - Fixed 3.3V SOT-23-5 Linear Voltage Regulators (LDO) ROHS; manufacturer: MICRONE (Nanjing Micro One Elec); Dropout Voltage: 200mV; Max Input Voltage: 6; Number of Regulators: 1; Output Configuration: Positive; Output Current: 500mA; Case/Package: SOT-23-5; Category: Voltage Regulators - Linear; ComponentLink3Description: On Semi datasheet online; ComponentLink3URL: https://datasheet.lcsc.com/lcsc/1811131510_MICRONE-Nanjing-Micro-One-Elec-ME6211C33M5G-N_C82942.pdf; Manufacturer 1: MICRONE (Nanjing Micro One Elec); Manufacturer Part Number 1: ME6211C33M5G-N; Min Output Voltage: 3.3V; Output Type: Fixed; Supplier 1: LCSC; Supplier Part Number 1: C82942
U2 = (no symbol name): IC PWR SWITCH N-CHAN 1:1 SOT23-6; Manufacturer: Texas Instruments; Max Input Voltage: 6.5V; Max Power Dissipation: 625mW; Min Input Voltage: 2.5V; Number of Outputs: 1; On-State Resistance: 85mR; Output Current: 1.5A; Case/Package: SOT-23; Category: Hot Swap Controllers; ComponentLink1Description: Online datasheet; ComponentLink1URL: https://datasheet.ciiva.com/15108/getdatasheetpartid-297517-15108509.pdf; Max Operating Temperature: 85°C; Max Supply Voltage: 6.5V; Min Operating Temperature: -40°C; Min Supply Voltage: 2.5V; Number of Pins: 6; Supplier 1: Digi-Key; Supplier 2: Farnell; Supplier 3: Mouser; Supplier Part Number 1: 296-32464-1-ND; Supplier Part Number 2: 3124082; Supplier Part Number 3: 595-TPS2553DBVR; Weight: 0.00127oz
U3 = (no symbol name): QFN-56_7x7 MCU; Manufacturer: Raspberry Pi; Manufacturer Part Number: RP2040; Category: Integrated Circuit; ComponentLink1Description: Online datasheet; ComponentLink1URL: https://datasheet.lcsc.com/lcsc/2108101830_Raspberry-Pi-RP2040_C2040.pdf; Manufacturer 1: Raspberry Pi; Manufacturer Part Number 1: RP2040; Supplier 1: LCSC; Supplier 1 Part Number: C2040
U4 = (no symbol name): FLASH - NOR Memory IC 32Mb (4M x 8) SPI - Quad I/O 133 MHz 8-SOIC; Manufacturer: Winbond; Frequency: 104MHz; Interface: SPI,Serial; Max Supply Voltage: 3.6V; Memory Type: FLASH,NOR; Min Supply Voltage: 2.7V; Operating Supply Voltage: 3V; Page Size: 256B; Case/Package: SOIC; Category: Flash; ComponentLink1Description: Online datasheet; ComponentLink1URL: http://www.winbond.com/resource-files/w25q32jv%20revg%2003272018%20plus.pdf; Density: 32MB; Max Operating Temperature: 85°C; Min Operating Temperature: -40°C; Number of Pins: 8; RoHS Compliant: Yes; Supplier 1: Digi-Key; Supplier Part Number 1: W25Q32JVSSIQ-ND
X1 = (no symbol name): Generic SMD xtal 3.2x2.5mm; Manufacturer: Multicomp; Manufacturer Part Number: 2853916; Supplier 1: LCSC; Supplier Part Number 1: C70565
